# Altium schematic sheet Sheet1.SchDoc
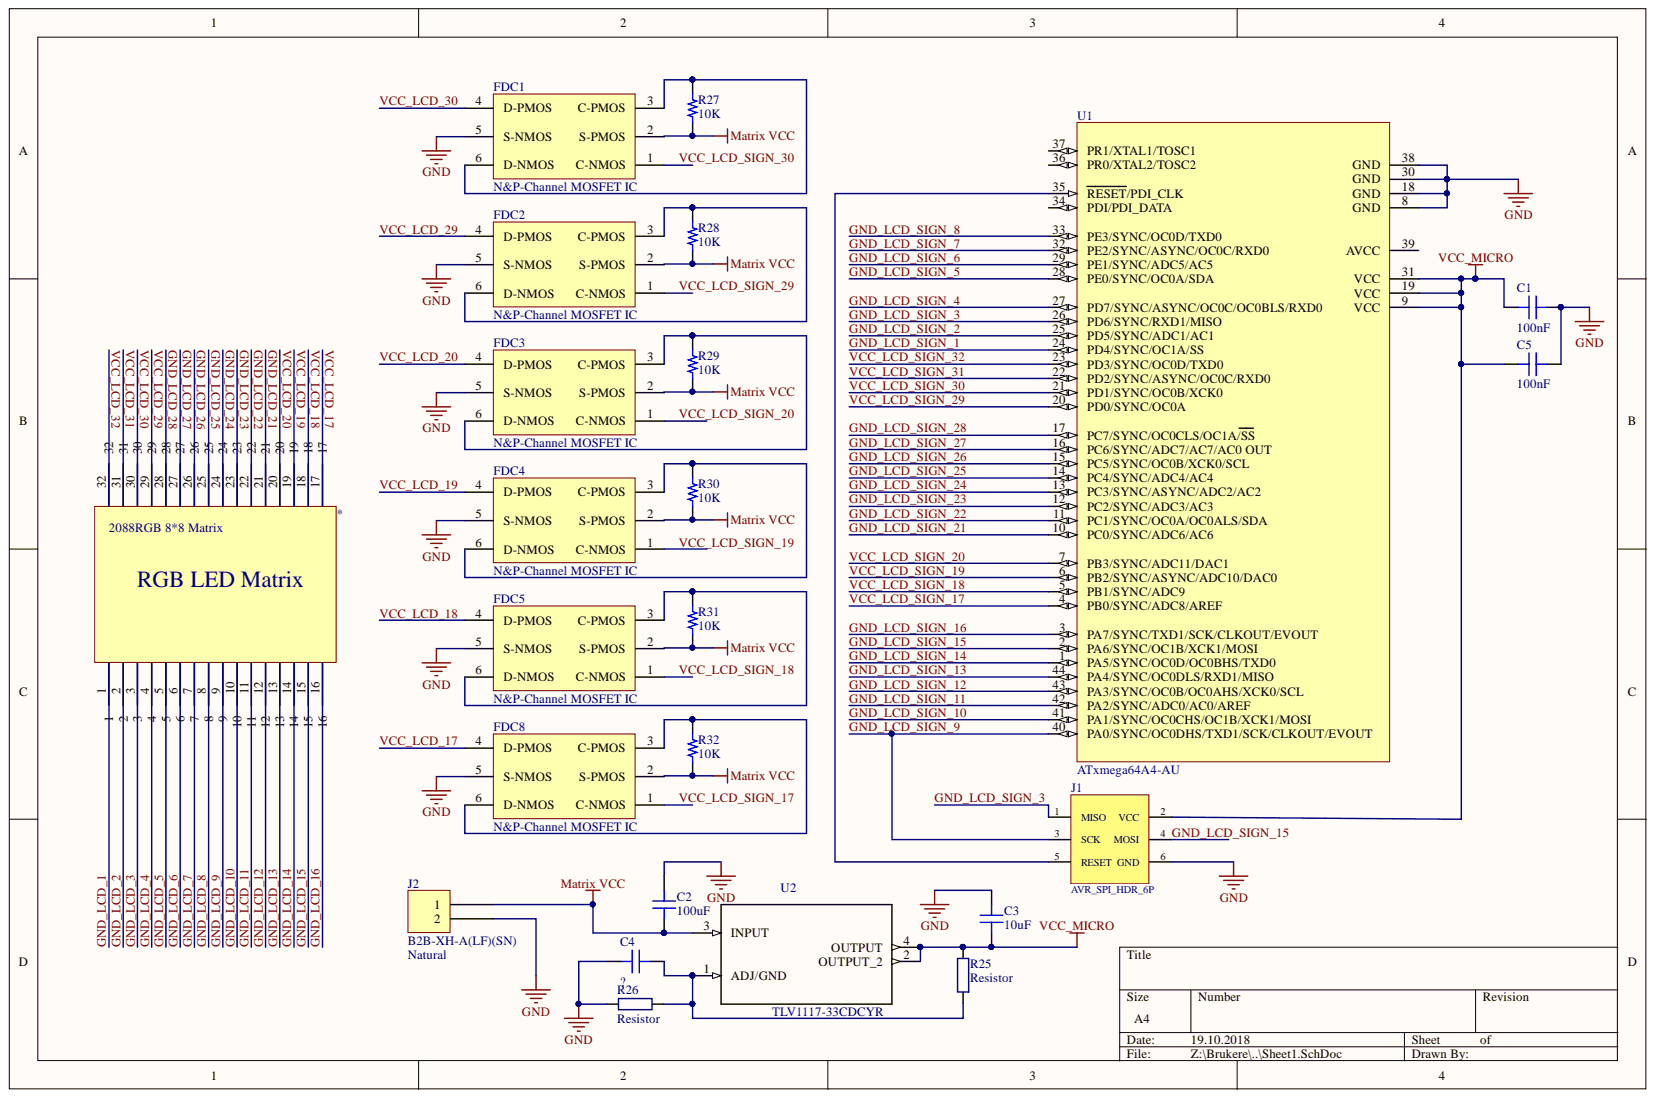
[diagram p0: Sheet1.SchDoc]

## Parts
*  2088RGB 8*8 Matrix  [PCBComponent_1]
C1  100nF  [CAP 0603/1608]
C2  100uF  [CAP 0603/1608]
C3  10uF  [CAP 0603/1608]
C4  ?  [CAP 0603/1608]
C5  100nF  [CAP 0603/1608]
FDC1  N&P-Channel MOSFET IC  [SSOT-6]
FDC2  N&P-Channel MOSFET IC  [SSOT-6]
FDC3  N&P-Channel MOSFET IC  [SSOT-6]
FDC4  N&P-Channel MOSFET IC  [SSOT-6]
FDC5  N&P-Channel MOSFET IC  [SSOT-6]
FDC8  N&P-Channel MOSFET IC  [SSOT-6]
J1  AVR_SPI_HDR_6P  [HEADER_3x2]
J2  B2B-XH-A(LF)(SN)  Colour: Natural  [JST XH B2B-XH-A]
R25  Resistor  [RESC0603(1608)_N]
R26  Resistor  [RESC0603(1608)_N]
R27  10K  [RES 0603/1608]
R28  10K  [RES 0603/1608]
R29  10K  [RES 0603/1608]
R30  10K  [RES 0603/1608]
R31  10K  [RES 0603/1608]
R32  10K  [RES 0603/1608]
U1  ATxmega64A4-AU  [44A_N]
U2  TLV1117-33CDCYR  [SOT230P700X180-4N]

## Nets
GND: C1.2 C2.2 C3.2 C4.2 C5.2 FDC1.5(S-NMOS) FDC2.5(S-NMOS) FDC3.5(S-NMOS) FDC4.5(S-NMOS) FDC5.5(S-NMOS) FDC8.5(S-NMOS) J1.6(GND) J2.2 R26.1 U1.8(GND) U1.18(GND) U1.30(GND) U1.38(GND)
Matrix VCC: C2.1 FDC1.2(S-PMOS) FDC2.2(S-PMOS) FDC3.2(S-PMOS) FDC4.2(S-PMOS) FDC5.2(S-PMOS) FDC8.2(S-PMOS) J2.1 R27.1 R28.1 R29.1 R30.1 R31.1 R32.1 U2.3(INPUT)
VCC_MICRO: C1.1 C3.1 C5.1 J1.2(VCC) R25.2 U1.9(VCC) U1.19(VCC) U1.31(VCC) U2.2(OUTPUT_2) U2.4(OUTPUT)
GND_LCD_1: *.1
GND_LCD_2: *.2
GND_LCD_3: *.3
GND_LCD_4: *.4
GND_LCD_5: *.5
GND_LCD_6: *.6
GND_LCD_7: *.7
GND_LCD_8: *.8
GND_LCD_9: *.9
GND_LCD_10: *.10
GND_LCD_11: *.11
GND_LCD_12: *.12
GND_LCD_13: *.13
GND_LCD_14: *.14
GND_LCD_15: *.15
GND_LCD_16: *.16
GND_LCD_21: *.21
GND_LCD_22: *.22
GND_LCD_23: *.23
GND_LCD_24: *.24
GND_LCD_25: *.25
GND_LCD_26: *.26
GND_LCD_27: *.27
GND_LCD_28: *.28
GND_LCD_SIGN_1: U1.24(PD4/SYNC/OC1A/SS)
GND_LCD_SIGN_2: U1.25(PD5/SYNC/ADC1/AC1)
GND_LCD_SIGN_3: J1.1(MISO) U1.26(PD6/SYNC/RXD1/MISO)
GND_LCD_SIGN_4: U1.27(PD7/SYNC/ASYNC/OC0C/OC0BLS/RXD0)
GND_LCD_SIGN_5: U1.28(PE0/SYNC/OC0A/SDA)
GND_LCD_SIGN_6: U1.29(PE1/SYNC/ADC5/AC5)
GND_LCD_SIGN_7: U1.32(PE2/SYNC/ASYNC/OC0C/RXD0)
GND_LCD_SIGN_8: U1.33(PE3/SYNC/OC0D/TXD0)
GND_LCD_SIGN_9: J1.3(SCK) U1.40(PA0/SYNC/OC0DHS/TXD1/SCK/CLKOUT/EVOUT)
GND_LCD_SIGN_10: U1.41(PA1/SYNC/OC0CHS/OC1B/XCK1/MOSI)
GND_LCD_SIGN_11: U1.42(PA2/SYNC/ADC0/AC0/AREF)
GND_LCD_SIGN_12: U1.43(PA3/SYNC/OC0B/OC0AHS/XCK0/SCL)
GND_LCD_SIGN_13: U1.44(PA4/SYNC/OC0DLS/RXD1/MISO)
GND_LCD_SIGN_14: U1.1(PA5/SYNC/OC0D/OC0BHS/TXD0)
GND_LCD_SIGN_15: J1.4(MOSI) U1.2(PA6/SYNC/OC1B/XCK1/MOSI)
GND_LCD_SIGN_16: U1.3(PA7/SYNC/TXD1/SCK/CLKOUT/EVOUT)
GND_LCD_SIGN_21: U1.10(PC0/SYNC/ADC6/AC6)
GND_LCD_SIGN_22: U1.11(PC1/SYNC/OC0A/OC0ALS/SDA)
GND_LCD_SIGN_23: U1.12(PC2/SYNC/ADC3/AC3)
GND_LCD_SIGN_24: U1.13(PC3/SYNC/ASYNC/ADC2/AC2)
GND_LCD_SIGN_25: U1.14(PC4/SYNC/ADC4/AC4)
GND_LCD_SIGN_26: U1.15(PC5/SYNC/OC0B/XCK0/SCL)
GND_LCD_SIGN_27: U1.16(PC6/SYNC/ADC7/AC7/AC0_OUT)
GND_LCD_SIGN_28: U1.17(PC7/SYNC/OC0CLS/OC1A/SS)
VCC_LCD_17: *.17 FDC8.4(D-PMOS)
VCC_LCD_18: *.18 FDC5.4(D-PMOS)
VCC_LCD_19: *.19 FDC4.4(D-PMOS)
VCC_LCD_20: *.20 FDC3.4(D-PMOS)
VCC_LCD_29: *.29 FDC2.4(D-PMOS)
VCC_LCD_30: *.30 FDC1.4(D-PMOS)
VCC_LCD_31: *.31
VCC_LCD_32: *.32
VCC_LCD_SIGN_17: FDC8.1(C-NMOS) U1.4(PB0/SYNC/ADC8/AREF)
VCC_LCD_SIGN_18: FDC5.1(C-NMOS) U1.5(PB1/SYNC/ADC9)
VCC_LCD_SIGN_19: FDC4.1(C-NMOS) U1.6(PB2/SYNC/ASYNC/ADC10/DAC0)
VCC_LCD_SIGN_20: FDC3.1(C-NMOS) U1.7(PB3/SYNC/ADC11/DAC1)
VCC_LCD_SIGN_29: FDC2.1(C-NMOS) U1.20(PD0/SYNC/OC0A)
VCC_LCD_SIGN_30: FDC1.1(C-NMOS) U1.21(PD1/SYNC/OC0B/XCK0)
VCC_LCD_SIGN_31: U1.22(PD2/SYNC/ASYNC/OC0C/RXD0)
VCC_LCD_SIGN_32: U1.23(PD3/SYNC/OC0D/TXD0)
NetC4_1: C4.1 R25.1 R26.2 U2.1(ADJ/GND)
NetFDC1_3: FDC1.3(C-PMOS) FDC1.6(D-NMOS) R27.2
NetFDC2_3: FDC2.3(C-PMOS) FDC2.6(D-NMOS) R28.2
NetFDC3_3: FDC3.3(C-PMOS) FDC3.6(D-NMOS) R29.2
NetFDC4_3: FDC4.3(C-PMOS) FDC4.6(D-NMOS) R30.2
NetFDC5_3: FDC5.3(C-PMOS) FDC5.6(D-NMOS) R31.2
NetFDC8_3: FDC8.3(C-PMOS) FDC8.6(D-NMOS) R32.2
NetJ1_5: J1.5(RESET) U1.35(RESET/PDI_CLK)

Not connected: U1 34(PDI/PDI_DATA) 36(PR0/XTAL2/TOSC2) 37(PR1/XTAL1/TOSC1) 39(AVCC)

## Library data of the parts
* = 2088RGB 8*8 Matrix: 8*8 RGB Led Matrix; Model: 2088RGB-1; Component Kind: Standard; Library Name: Schlib1.SchLib; Schematic: http://selfbuilt.net/datasheets/2088RGB-5-datasheet.jpg
C1-C5 = C1608C0G1H820J080AA: CAP CER 82PF 50V C0G 0603; Manufacturer: TDK Corporation; Database Table Name: Capacitor - Ceramic; TemperatureCoefficient: C0G, NP0; TemperatureRange: -55C ~ 125C; Tolerance: 5%; Component Kind: Standard; ComponentLink1Description: Datasheet; ComponentLink1URL: https://product.tdk.com/info/en/catalog/datasheets/mlcc_commercial_general_en.pdf; ComponentLink2Description: Digi-Key listing; Device Package: 0603 (1608 Metric); Library Name: Celestial Library Database.DbLib; Supplier 1: Digi-Key; Type: -; Voltage: 50V
  C1 C5: Value: 100nF
  C2: Value: 100uF
  C3: Value: 10uF
  C4: Value: ?
FDC1-FDC5 FDC8 = FDC633C: Component Kind: Standard; Library Name: IC Chips.SchLib; Package: SSOT-8; Schematic: http://www.mouser.com/ds/2/149/FDC6333C-1007236.pdf
J2 = B2B-XH-A(LF)(SN): CONN HEADER XH TOP 2POS 2.5MM; Manufacturer: JST Sales America Inc.; Database Table Name: Connector - Rectangular; Number Of Positions: 2; Pitch: 0.098" (2.50mm); Component Kind: Standard; ComponentLink1Description: Datasheet; ComponentLink1URL: http://www.jst-mfg.com/product/pdf/eng/eXH.pdf; ComponentLink2Description: Digi-Key Listing; ComponentLink2URL: http://www.digikey.com/product-detail/en/jst-sales-america-inc/B2B-XH-A(LF)(SN)/455-2247-ND/1651045; Contact Finish: Tin; Fastening Type: Detent Lock; Library Name: Celestial Library Database.DbLib; Number Of Rows: 1; PartId: 16449; Part Number: B2B-XH-A(LF)(SN); Price: 0,15; Series: XH; Supplier 1: Digi-Key; Supplier Part Number 1: 455-2247-ND; Type: Header, Shrouded
R27-R32 = ERJ-3EKF2320V: RES SMD 232 OHM 1% 1/10W 0603; Database Table Name: Resistor - Chip; Component Kind: Standard; Library Name: Celestial Library Database.DbLib; Part Number: ERJ-3EKF2320V; Value: 10K
U1 = ATxmega64A4-AU: 8/16-bit AVR XMEGA A4 Microcontroller, 1.6-3.6V, 64KB + 4KB Flash, 2KB EEPROM, 4KB SRAM, 44-pin TQFP, Industrial Grade (-40°C to 85°C), Pb-Free; Component Kind: Standard; ComponentLink1Description: Manufacturer Link; ComponentLink1URL: http://www.atmel.com/products/avr/default.asp?; ComponentLink2Description: ATxmega16A4/32A4/64A4/128A4 Datasheet; ComponentLink2URL: http://www.atmel.com/dyn/resources/prod_documents/doc8069.pdf; ComponentLink3Description: Atmel.com Home; ComponentLink3URL: http://www.atmel.com; DatasheetVersion: revN, Feb-2010; Library Name: Atmel Microcontroller 8-16-Bit AVR XMEGA.IntLib; PackageDescription: 44-Pin Plastic Thin Profile Quad Flat Pack, 10 x 10 x 1.0 mm Body, 0.8 mm Pitch (TQFP); PackageReference: 44A; PackageVersion: rev.B, Oct-2001; Published: 1-Apr-2010; Supplier 1: Digi-Key; Supplier 2: Farnell; Supplier 3: Mouser; Supplier Part Number 1: ATXMEGA64A4-AU-ND; Supplier Part Number 2: 1748560; Supplier Part Number 3: 556-ATXMEGA64A4-AU; Publisher: Altium Limited
U2 = TLV1117-33CDCYR: IC REG LIN 3.3V 800MA SOT223-4; MF: Texas Instruments; Availability: Good; Component Kind: Standard; Library Name: TLV1117-33CDCYR.IntLib; MP: TLV1117-33CDCYR; Package: SOT-223-4 Texas Instruments; Price: 0.35 USD
J1 = AVR_SPI_HDR_6P: Component Kind: Standard; Library Name: AVR_SPI_HDR_6P.SchLib
R25 R26 = Resistor: Component Kind: Standard; Library Name: Resistor.SchLib; Value: ?
